# Altium schematic sheet drivers.SchDoc(drivers)
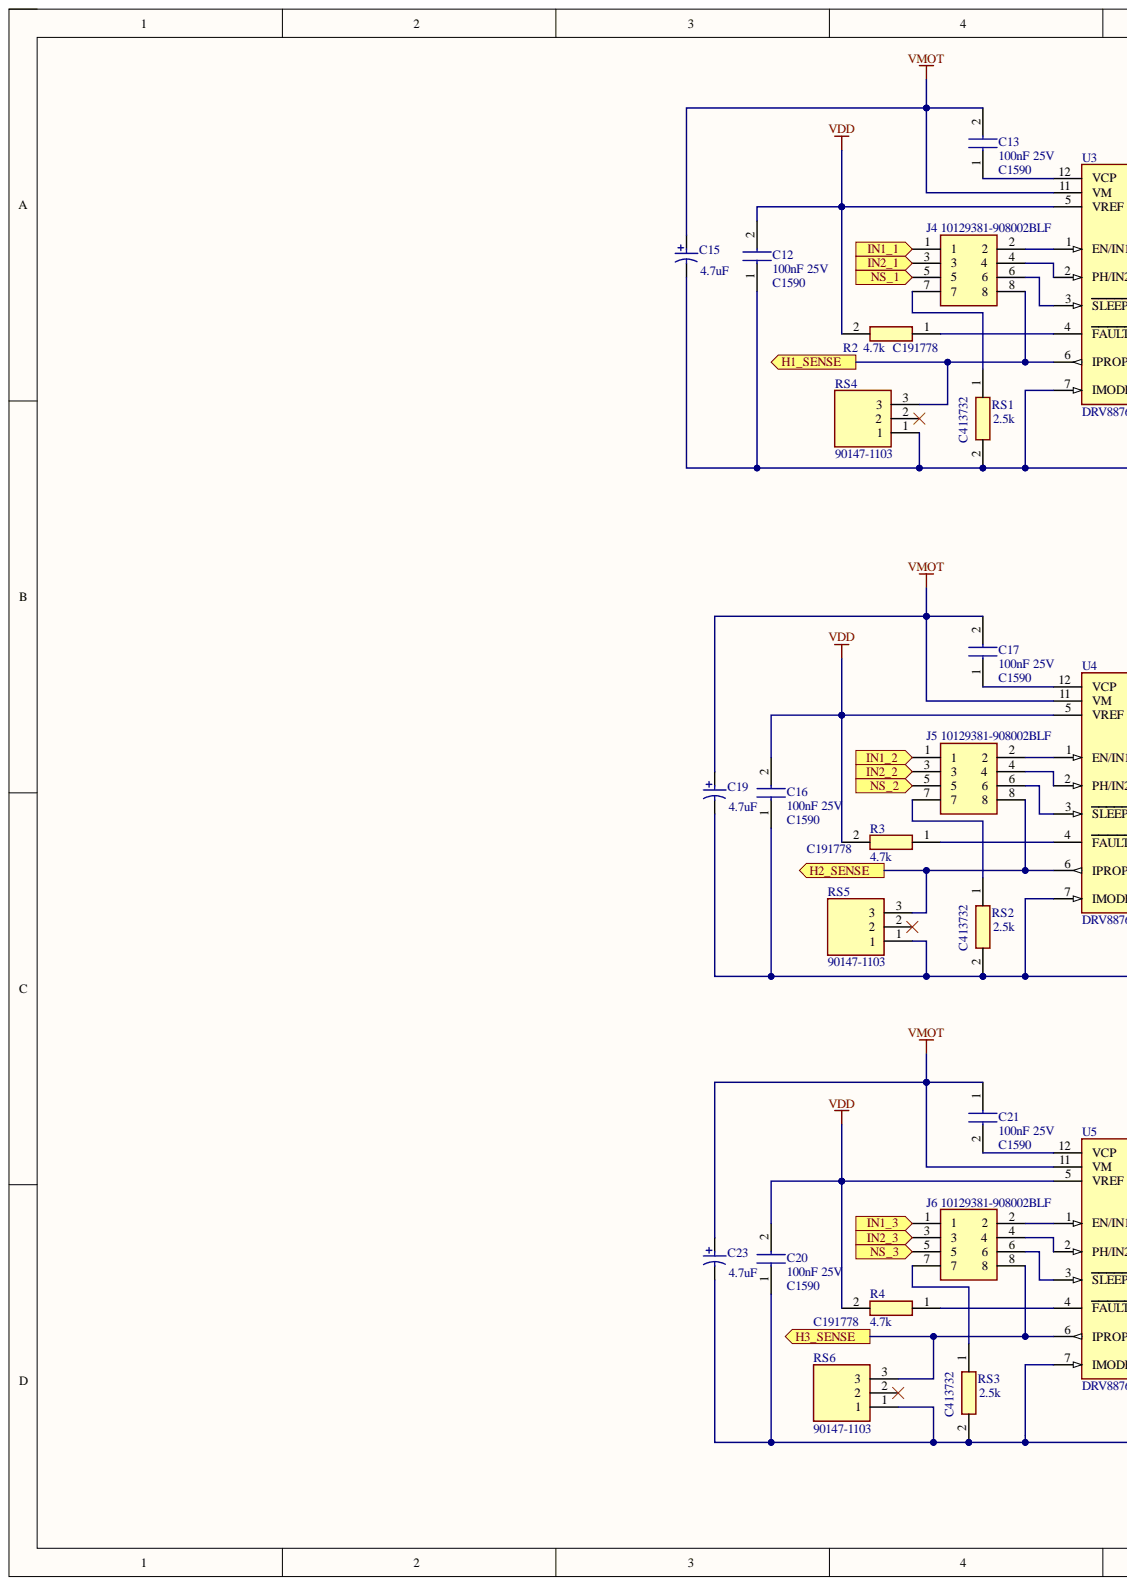
[diagram p0: drivers.SchDoc, left]
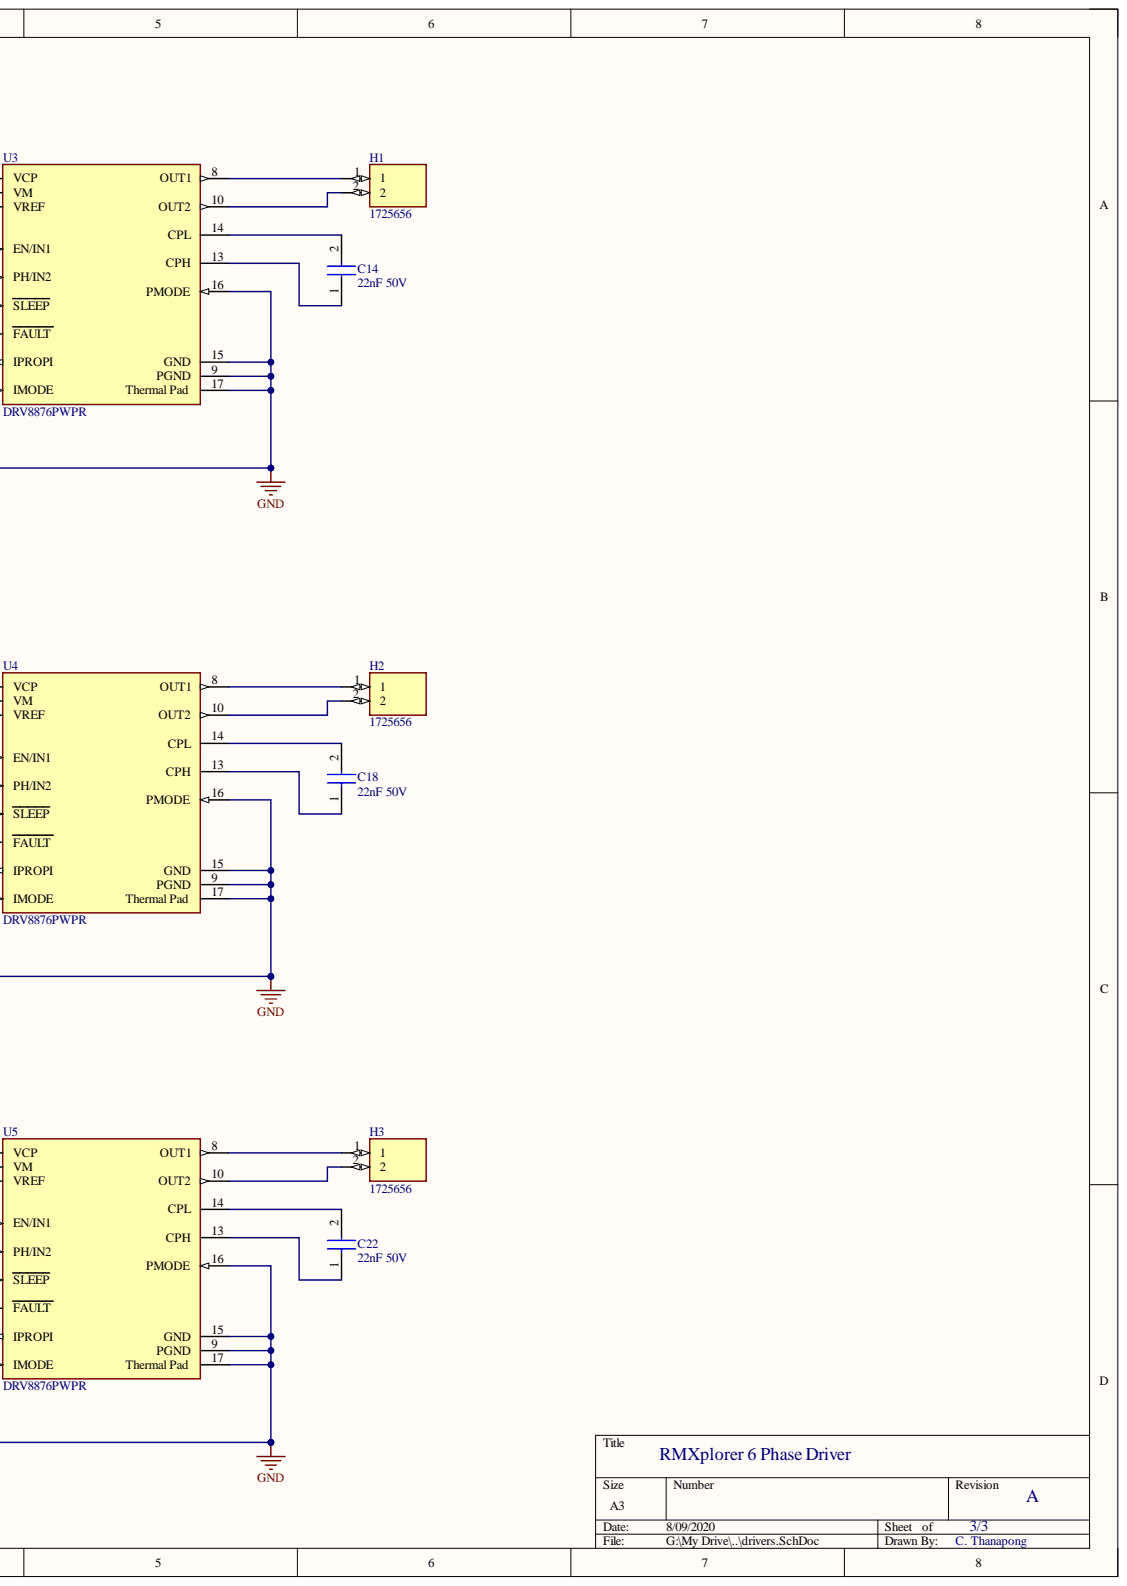
[diagram p1: drivers.SchDoc, right]

## Parts
C12  100nF 25V  Supplier Part Number 1: C1590  [CAPC1608X90N]
C13  100nF 25V  Supplier Part Number 1: C1590  [CAPC1608X90N]
C14  22nF 50V  [CAPC1608X90N]
C15  4.7uF  [NIC-CAPA2-5X5.8_V]
C16  100nF 25V  Supplier Part Number 1: C1590  [CAPC1608X90N]
C17  100nF 25V  Supplier Part Number 1: C1590  [CAPC1608X90N]
C18  22nF 50V  [CAPC1608X90N]
C19  4.7uF  [NIC-CAPA2-5X5.8_V]
C20  100nF 25V  Supplier Part Number 1: C1590  [CAPC1608X90N]
C21  100nF 25V  Supplier Part Number 1: C1590  [CAPC1608X90N]
C22  22nF 50V  [CAPC1608X90N]
C23  4.7uF  [NIC-CAPA2-5X5.8_V]
H1  1725656  [1725656]
H2  1725656  [1725656]
H3  1725656  [1725656]
J4  10129381-908002BLF  [HDRV8W67P254_2X4_1016X483X858P]
J5  10129381-908002BLF  [HDRV8W67P254_2X4_1016X483X858P]
J6  10129381-908002BLF  [HDRV8W67P254_2X4_1016X483X858P]
R2  4.7k  Supplier Part Number 1: C191778  [RESC1608X55N]
R3  4.7k  Supplier Part Number 1: C191778  [RESC1608X55N]
R4  4.7k  Supplier Part Number 1: C191778  [RESC1608X55N]
RS1  2.5k  Supplier Part Number 1: C413732  [RESC1608X55N]
RS2  2.5k  Supplier Part Number 1: C413732  [RESC1608X55N]
RS3  2.5k  Supplier Part Number 1: C413732  [RESC1608X55N]
RS4  90147-1103  [SHDR3W70P0X254_1X3_747X244X870P]
RS5  90147-1103  [SHDR3W70P0X254_1X3_747X244X870P]
RS6  90147-1103  [SHDR3W70P0X254_1X3_747X244X870P]
U3  DRV8876PWPR  [FP-PWP0016C-MFG]
U4  DRV8876PWPR  [FP-PWP0016C-MFG]
U5  DRV8876PWPR  [FP-PWP0016C-MFG]

## Ports
H1_SENSE, H2_SENSE, H3_SENSE, IN1_1, IN1_2, IN1_3, IN2_1, IN2_2, IN2_3, NS_1, NS_2, NS_3

## Nets
GND: C12.1 C15.2 C16.1 C19.2 C20.1 C23.2 RS1.2 RS2.2 RS3.2 RS4.1 RS5.1 RS6.1 U3.7(IMODE) U3.9(PGND) U3.15(GND) U3.16(PMODE) U3.17(Thermal_Pad) U4.7(IMODE) U4.9(PGND) U4.15(GND) U4.16(PMODE) U4.17(Thermal_Pad) U5.7(IMODE) U5.9(PGND) U5.15(GND) U5.16(PMODE) U5.17(Thermal_Pad)
VDD: C12.2 C16.2 C20.2 R2.2 R3.2 R4.2 U3.5(VREF) U4.5(VREF) U5.5(VREF)
VMOT: C13.2 C15.1 C17.2 C19.1 C21.1 C23.1 U3.11(VM) U4.11(VM) U5.11(VM)
H1_SENSE: J4.8 RS4.3 U3.6(IPROPI) ; port H1_SENSE
H2_SENSE: J5.8 RS5.3 U4.6(IPROPI) ; port H2_SENSE
H3_SENSE: J6.8 RS6.3 U5.6(IPROPI) ; port H3_SENSE
IN1_1: J4.1 ; port IN1_1
IN1_2: J5.1 ; port IN1_2
IN1_3: J6.1 ; port IN1_3
IN2_1: J4.3 ; port IN2_1
IN2_2: J5.3 ; port IN2_2
IN2_3: J6.3 ; port IN2_3
NS_1: J4.5 ; port NS_1
NS_2: J5.5 ; port NS_2
NS_3: J6.5 ; port NS_3
NetC13_1: C13.1 U3.12(VCP)
NetC14_1: C14.1 U3.13(CPH)
NetC14_2: C14.2 U3.14(CPL)
NetC17_1: C17.1 U4.12(VCP)
NetC18_1: C18.1 U4.13(CPH)
NetC18_2: C18.2 U4.14(CPL)
NetC21_2: C21.2 U5.12(VCP)
NetC22_1: C22.1 U5.13(CPH)
NetC22_2: C22.2 U5.14(CPL)
NetH1_1: H1.1 U3.8(OUT1)
NetH1_2: H1.2 U3.10(OUT2)
NetH2_1: H2.1 U4.8(OUT1)
NetH2_2: H2.2 U4.10(OUT2)
NetH3_1: H3.1 U5.8(OUT1)
NetH3_2: H3.2 U5.10(OUT2)
NetJ4_2: J4.2 U3.1(EN/IN1)
NetJ4_4: J4.4 U3.2(PH/IN2)
NetJ4_6: J4.6 U3.3(SLEEP)
NetJ4_7: J4.7 RS1.1
NetJ5_2: J5.2 U4.1(EN/IN1)
NetJ5_4: J5.4 U4.2(PH/IN2)
NetJ5_6: J5.6 U4.3(SLEEP)
NetJ5_7: J5.7 RS2.1
NetJ6_2: J6.2 U5.1(EN/IN1)
NetJ6_4: J6.4 U5.2(PH/IN2)
NetJ6_6: J6.6 U5.3(SLEEP)
NetJ6_7: J6.7 RS3.1
NetR2_1: R2.1 U3.4(FAULT)
NetR3_1: R3.1 U4.4(FAULT)
NetR4_1: R4.1 U5.4(FAULT)

Not connected: RS4 2; RS5 2; RS6 2

## Library data of the parts
C12 C13 C16 C17 C20 C21 = CL10B104KA8NNNC: Capacitor; Manufacturer_Name: Samsung Electro-Mechanics; Arrow Part Number: CL10B104KA8NNNC; Arrow Price/Stock: https://www.arrow.com/en/products/cl10b104ka8nnnc/samsung-electro-mechanics; Component Kind: Standard; Datasheet Link: http://media.digikey.com/pdf/Data%20Sheets/Samsung%20PDFs/CL_Series_MLCC_ds.pdf; Height: 0.9mm; Manufacturer_Part_Number: CL10B104KA8NNNC; Supplier 1: LCSC
C14 C18 C22 = CL10B223KB8NNNC: Capacitor; Manufacturer_Name: Samsung Electro-Mechanics; Arrow Part Number: CL10B223KB8NNNC; Arrow Price/Stock: https://www.arrow.com/en/products/cl10b223kb8nnnc/samsung-electro-mechanics; Component Kind: Standard; Datasheet Link: http://uk.rs-online.com/web/p/products/7665402; Height: 0.9mm; Manufacturer_Part_Number: CL10B223KB8NNNC
C15 C19 C23 = CMP-0687-00025-1: Aluminum Electrolytic Capacitor, UD Series, Low Impedance, 4.7 uF, 50 V, + / - 20%, -55 to 105 degC, Chip Type, 5 x 5.8 mm D x L, Pb-Free, Reel; Manufacturer: Nichicon; PartNumber: UUD1H4R7MCL1GS; Endurance Time: 2000 h; Impedance: 1.52 Ohm; Packing: Dia382mm Reel; Rated Ripple Current (at 100kHz): 85 mA; Rated Voltage: 50 V; Tolerance Rated Capacitance: -20 to 20%; Component Kind: Standard; ComponentLink1Description: Manufacturer URL; ComponentLink1URL: http://www.nichicon.co.jp/; ComponentLink2Description: Datasheet; ComponentLink2URL: http://products.nichicon.co.jp/en/pdf/XJA043/e-ud.pdf; ComponentLink3Description: Package Specification; ComponentLink3URL: http://products.nichicon.co.jp/en/pdf/XJA043/e-ud.pdf; DatasheetVersion: 08/2012; Diameter: 5 mm; Length: 5.8 mm; Library Name: Altium Content Vault; Mounting Technology: Surface Mount; PackageDescription: 2-Pin Surface Mount Device, Diameter 5 mm, Height 5.8 mm, Pitch 4.4 mm; PackageReference: CAPAE-D5H5.8; Rated Capacitance: 4.7 uF; RoHS: TRUE
H1-H3 = 1725656: Connector; Manufacturer_Name: Phoenix Contact; Arrow Part Number: 1725656; Arrow Price/Stock: https://www.arrow.com/en/products/1725656/phoenix-contact; Component Kind: Standard; Datasheet Link: https://www.phoenixcontact.com/online/portal/gb?uri=pxc-oc-itemdetail:pid=1725656&library=gben&tab=1; Height: 8mm; Manufacturer_Part_Number: 1725656; Mouser Part Number: 651-1725656; Mouser Price/Stock: https://www.mouser.co.uk/ProductDetail/Phoenix-Contact/1725656?qs=Ul7CXFMnlWWQeccayYbRmw%3D%3D
J4-J6 = 10129381-908002BLF: Connector; Manufacturer_Name: Amphenol; Arrow Part Number: 10129381-908002BLF; Component Kind: Standard; Datasheet Link: https://cdn.amphenol-icc.com/media/wysiwyg/files/drawing/10129381.pdf; Height: 8.58mm; Manufacturer_Part_Number: 10129381-908002BLF; Mouser Part Number: 649-1012938190802BLF; Mouser Price/Stock: https://www.mouser.co.uk/ProductDetail/Amphenol-FCI/10129381-908002BLF?qs=DXv0QSHKF4wp0EFafi7%252BkA%3D%3D; Supplier 1: Mouser; Supplier Part Number 1: 649-1012938190802BLF
R2-R4 RS1-RS3 = ERJ3RBD4701V: Resistor; Manufacturer_Name: Panasonic; Arrow Part Number: ERJ3RBD4701V; Component Kind: Standard; Datasheet Link: http://docs-europe.electrocomponents.com/webdocs/13ba/0900766b813ba21b.pdf; Height: 0.55mm; Manufacturer_Part_Number: ERJ3RBD4701V; Supplier 1: LCSC
RS4-RS6 = 90147-1103: Capacitor; Manufacturer_Name: Molex; Arrow Part Number: 90147-1103; Component Kind: Standard; Datasheet Link: https://www.molex.com/pdm_docs/sd/901471103_sd.pdf; Height: 8.7mm; Manufacturer_Part_Number: 90147-1103; Mouser Part Number: 538-90147-1103; Mouser Price/Stock: https://www.mouser.co.uk/ProductDetail/Molex/90147-1103?qs=zXrbR4Jv0OeHqzvtbqVfQw%3D%3D
U3-U5 = CMP-04915-000007-1: PWR MGMT MOTOR/FAN DRIVER; Component Kind: Standard; Library Name: Altium Content Vault
